# Altium schematic sheet FPGA_Power.SchDoc(FPGA_Power)
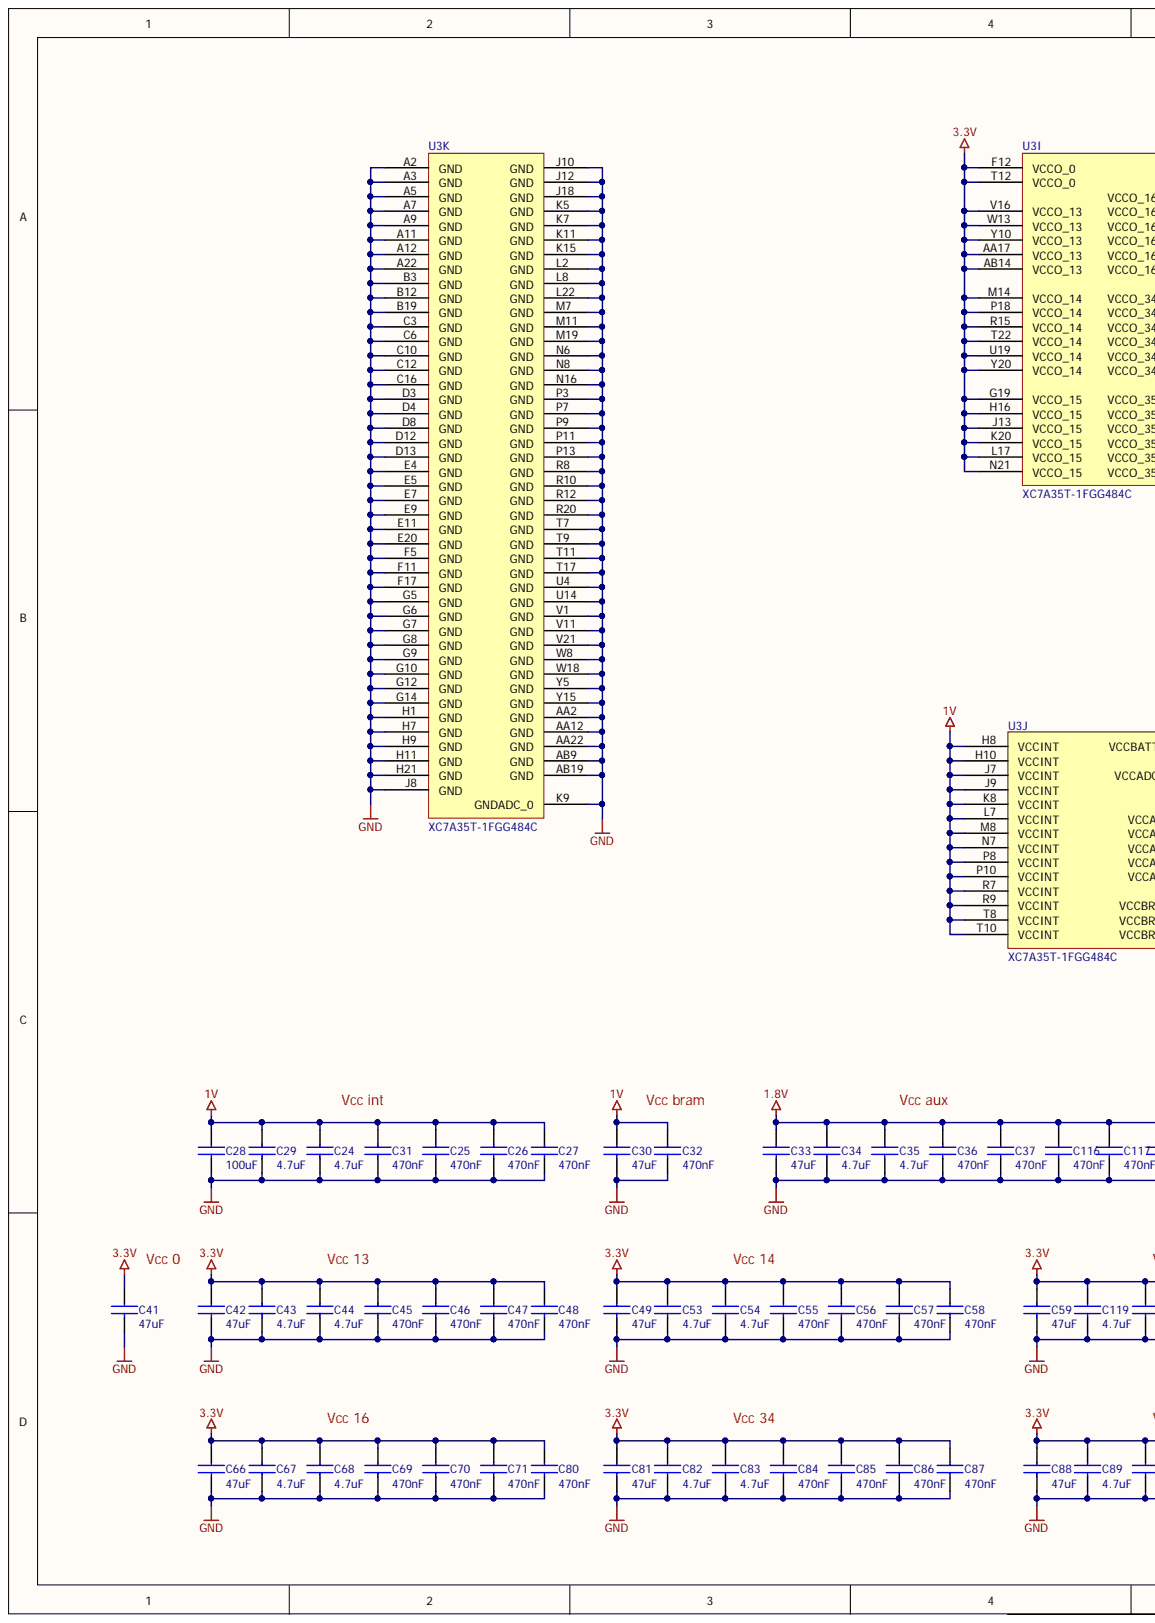
[diagram p0: FPGA_Power.SchDoc, left]
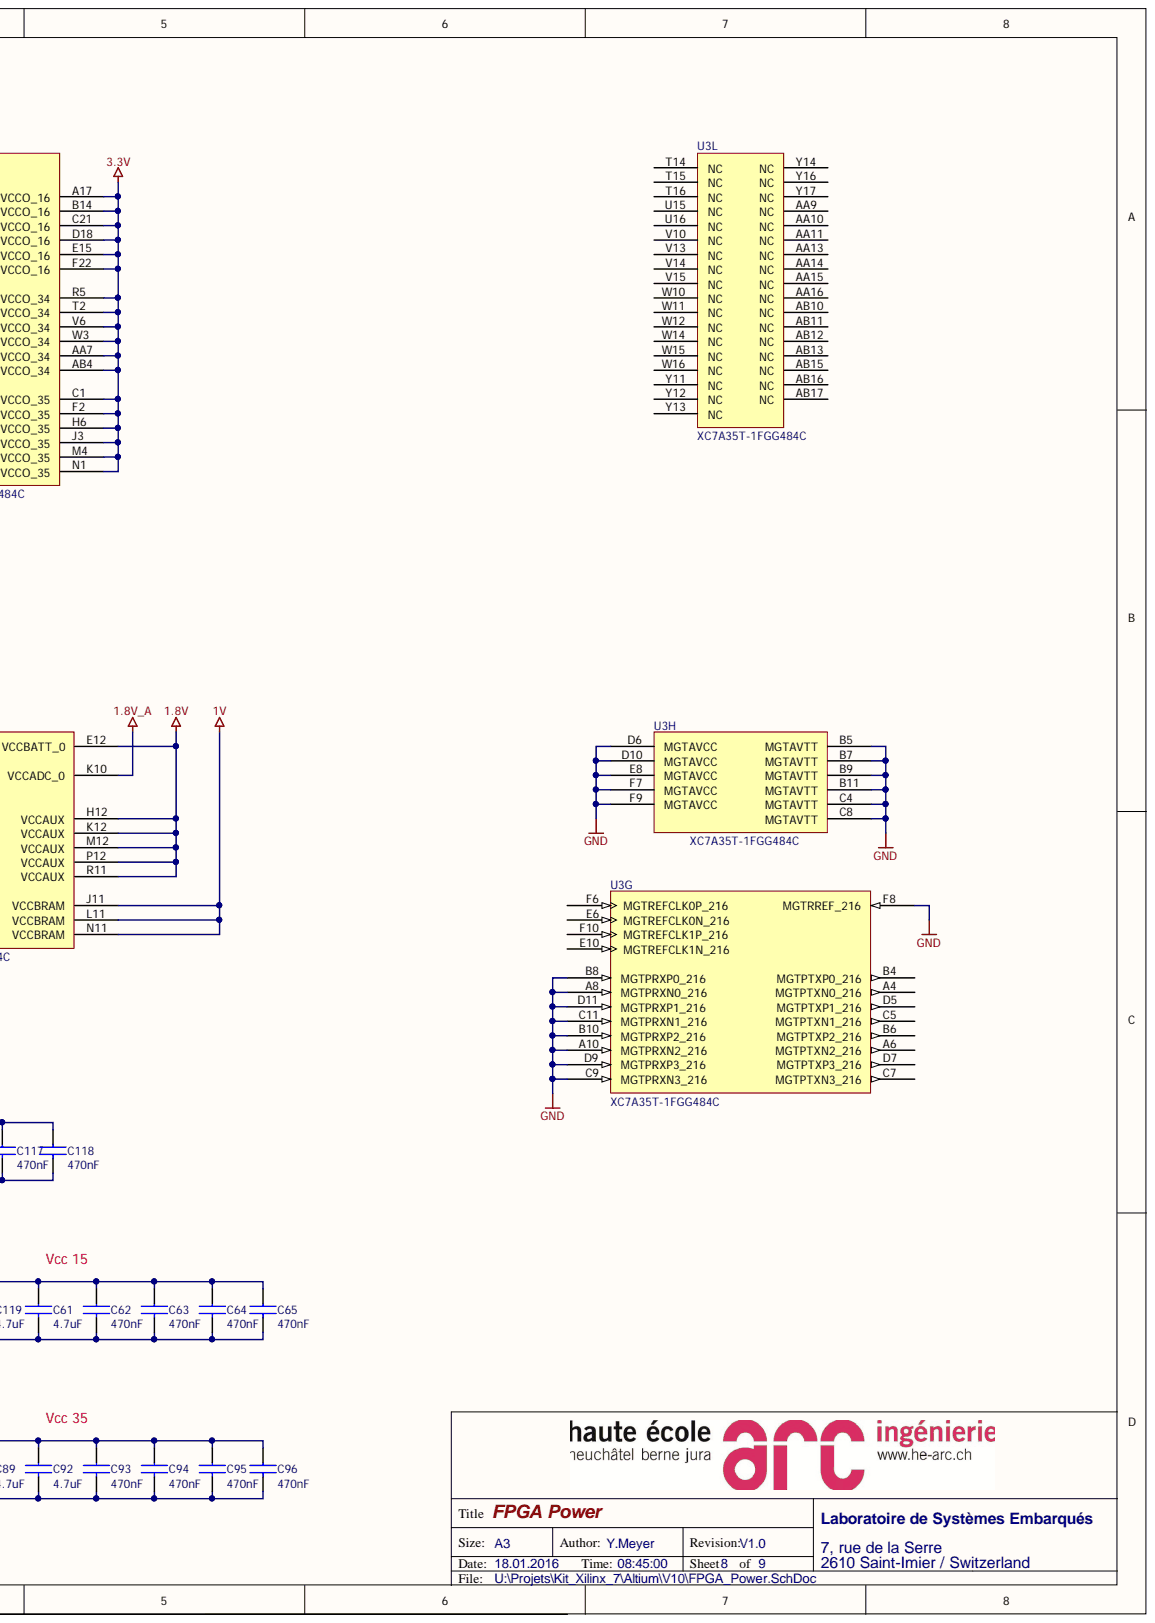
[diagram p1: FPGA_Power.SchDoc, right]

## Parts
C24  value 4.7uF  [080501]  (hidden comment: GRM21BR71A475KA73)
C25  value 470nF  [060301]  (hidden comment: GRM188R70J474KA01)
C26  value 470nF  [060301]  (hidden comment: GRM188R70J474KA01)
C27  value 470nF  [060301]  (hidden comment: GRM188R70J474KA01)
C28  value 100uF  [121001]  (hidden comment: GRM32ER60J107ME20L)
C29  value 4.7uF  [080501]  (hidden comment: GRM21BR71A475KA73)
C30  value 47uF  [121001]  (hidden comment: GRM32ER70J476ME20L)
C31  value 470nF  [060301]  (hidden comment: GRM188R70J474KA01)
C32  value 470nF  [060301]  (hidden comment: GRM188R70J474KA01)
C33  value 47uF  [121001]  (hidden comment: GRM32ER70J476ME20L)
C34  value 4.7uF  [080501]  (hidden comment: GRM21BR71A475KA73)
C35  value 4.7uF  [080501]  (hidden comment: GRM21BR71A475KA73)
C36  value 470nF  [060301]  (hidden comment: GRM188R70J474KA01)
C37  value 470nF  [060301]  (hidden comment: GRM188R70J474KA01)
C41  value 47uF  [121001]  (hidden comment: GRM32ER70J476ME20L)
C42  value 47uF  [121001]  (hidden comment: GRM32ER70J476ME20L)
C43  value 4.7uF  [080501]  (hidden comment: GRM21BR71A475KA73)
C44  value 4.7uF  [080501]  (hidden comment: GRM21BR71A475KA73)
C45  value 470nF  [060301]  (hidden comment: GRM188R70J474KA01)
C46  value 470nF  [060301]  (hidden comment: GRM188R70J474KA01)
C47  value 470nF  [060301]  (hidden comment: GRM188R70J474KA01)
C48  value 470nF  [060301]  (hidden comment: GRM188R70J474KA01)
C49  value 47uF  [121001]  (hidden comment: GRM32ER70J476ME20L)
C53  value 4.7uF  [080501]  (hidden comment: GRM21BR71A475KA73)
C54  value 4.7uF  [080501]  (hidden comment: GRM21BR71A475KA73)
C55  value 470nF  [060301]  (hidden comment: GRM188R70J474KA01)
C56  value 470nF  [060301]  (hidden comment: GRM188R70J474KA01)
C57  value 470nF  [060301]  (hidden comment: GRM188R70J474KA01)
C58  value 470nF  [060301]  (hidden comment: GRM188R70J474KA01)
C59  value 47uF  [121001]  (hidden comment: GRM32ER70J476ME20L)
C61  value 4.7uF  [080501]  (hidden comment: GRM21BR71A475KA73)
C62  value 470nF  [060301]  (hidden comment: GRM188R70J474KA01)
C63  value 470nF  [060301]  (hidden comment: GRM188R70J474KA01)
C64  value 470nF  [060301]  (hidden comment: GRM188R70J474KA01)
C65  value 470nF  [060301]  (hidden comment: GRM188R70J474KA01)
C66  value 47uF  [121001]  (hidden comment: GRM32ER70J476ME20L)
C67  value 4.7uF  [080501]  (hidden comment: GRM21BR71A475KA73)
C68  value 4.7uF  [080501]  (hidden comment: GRM21BR71A475KA73)
C69  value 470nF  [060301]  (hidden comment: GRM188R70J474KA01)
C70  value 470nF  [060301]  (hidden comment: GRM188R70J474KA01)
C71  value 470nF  [060301]  (hidden comment: GRM188R70J474KA01)
C80  value 470nF  [060301]  (hidden comment: GRM188R70J474KA01)
C81  value 47uF  [121001]  (hidden comment: GRM32ER70J476ME20L)
C82  value 4.7uF  [080501]  (hidden comment: GRM21BR71A475KA73)
C83  value 4.7uF  [080501]  (hidden comment: GRM21BR71A475KA73)
C84  value 470nF  [060301]  (hidden comment: GRM188R70J474KA01)
C85  value 470nF  [060301]  (hidden comment: GRM188R70J474KA01)
C86  value 470nF  [060301]  (hidden comment: GRM188R70J474KA01)
C87  value 470nF  [060301]  (hidden comment: GRM188R70J474KA01)
C88  value 47uF  [121001]  (hidden comment: GRM32ER70J476ME20L)
C89  value 4.7uF  [080501]  (hidden comment: GRM21BR71A475KA73)
C92  value 4.7uF  [080501]  (hidden comment: GRM21BR71A475KA73)
C93  value 470nF  [060301]  (hidden comment: GRM188R70J474KA01)
C94  value 470nF  [060301]  (hidden comment: GRM188R70J474KA01)
C95  value 470nF  [060301]  (hidden comment: GRM188R70J474KA01)
C96  value 470nF  [060301]  (hidden comment: GRM188R70J474KA01)
C116  value 470nF  [060301]  (hidden comment: GRM188R70J474KA01)
C117  value 470nF  [060301]  (hidden comment: GRM188R70J474KA01)
C118  value 470nF  [060301]  (hidden comment: GRM188R70J474KA01)
C119  value 4.7uF  [080501]  (hidden comment: GRM21BR71A475KA73)
U3  XC7A35T-1FGG484C  [FGG484]  (parts G,H,I,J,K,L)

## Nets
1.8V: C33.1 C34.1 C35.1 C36.1 C37.1 C116.1 C117.1 C118.1 U3.E12(VCCBATT_0) U3.H12(VCCAUX) U3.K12(VCCAUX) U3.M12(VCCAUX) U3.P12(VCCAUX) U3.R11(VCCAUX)
1.8V_A: U3.K10(VCCADC_0)
1V: C24.1 C25.1 C26.1 C27.1 C28.1 C29.1 C30.1 C31.1 C32.1 U3.H8(VCCINT) U3.H10(VCCINT) U3.J7(VCCINT) U3.J9(VCCINT) U3.J11(VCCBRAM) U3.K8(VCCINT) U3.L7(VCCINT) U3.L11(VCCBRAM) U3.M8(VCCINT) U3.N7(VCCINT) U3.N11(VCCBRAM) U3.P8(VCCINT) U3.P10(VCCINT) U3.R7(VCCINT) U3.R9(VCCINT) U3.T8(VCCINT) U3.T10(VCCINT)
3.3V: C41.1 C42.1 C43.1 C44.1 C45.1 C46.1 C47.1 C48.1 C49.1 C53.1 C54.1 C55.1 C56.1 C57.1 C58.1 C59.1 C61.1 C62.1 C63.1 C64.1 C65.1 C66.1 C67.1 C68.1 C69.1 C70.1 C71.1 C80.1 C81.1 C82.1 C83.1 C84.1 C85.1 C86.1 C87.1 C88.1 C89.1 C92.1 C93.1 C94.1 C95.1 C96.1 C119.1 U3.A17(VCCO_16) U3.AA7(VCCO_34) U3.AA17(VCCO_13) U3.AB4(VCCO_34) U3.AB14(VCCO_13) U3.B14(VCCO_16) U3.C1(VCCO_35) U3.C21(VCCO_16) U3.D18(VCCO_16) U3.E15(VCCO_16) U3.F2(VCCO_35) U3.F12(VCCO_0) U3.F22(VCCO_16) U3.G19(VCCO_15) U3.H6(VCCO_35) U3.H16(VCCO_15) U3.J3(VCCO_35) U3.J13(VCCO_15) U3.K20(VCCO_15) U3.L17(VCCO_15) U3.M4(VCCO_35) U3.M14(VCCO_14) U3.N1(VCCO_35) U3.N21(VCCO_15) U3.P18(VCCO_14) U3.R5(VCCO_34) U3.R15(VCCO_14) U3.T2(VCCO_34) U3.T12(VCCO_0) U3.T22(VCCO_14) U3.U19(VCCO_14) U3.V6(VCCO_34) U3.V16(VCCO_13) U3.W3(VCCO_34) U3.W13(VCCO_13) U3.Y10(VCCO_13) U3.Y20(VCCO_14)
GND: C24.2 C25.2 C26.2 C27.2 C28.2 C29.2 C30.2 C31.2 C32.2 C33.2 C34.2 C35.2 C36.2 C37.2 C41.2 C42.2 C43.2 C44.2 C45.2 C46.2 C47.2 C48.2 C49.2 C53.2 C54.2 C55.2 C56.2 C57.2 C58.2 C59.2 C61.2 C62.2 C63.2 C64.2 C65.2 C66.2 C67.2 C68.2 C69.2 C70.2 C71.2 C80.2 C81.2 C82.2 C83.2 C84.2 C85.2 C86.2 C87.2 C88.2 C89.2 C92.2 C93.2 C94.2 C95.2 C96.2 C116.2 C117.2 C118.2 C119.2 U3.A2(GND) U3.A3(GND) U3.A5(GND) U3.A7(GND) U3.A8(MGTPRXN0_216) U3.A9(GND) U3.A10(MGTPRXN2_216) U3.A11(GND) U3.A12(GND) U3.A22(GND) U3.AA2(GND) U3.AA12(GND) U3.AA22(GND) U3.AB9(GND) U3.AB19(GND) U3.B3(GND) U3.B5(MGTAVTT) U3.B7(MGTAVTT) U3.B8(MGTPRXP0_216) U3.B9(MGTAVTT) U3.B10(MGTPRXP2_216) U3.B11(MGTAVTT) U3.B12(GND) U3.B19(GND) U3.C3(GND) U3.C4(MGTAVTT) U3.C6(GND) U3.C8(MGTAVTT) U3.C9(MGTPRXN3_216) U3.C10(GND) U3.C11(MGTPRXN1_216) U3.C12(GND) U3.C16(GND) U3.D3(GND) U3.D4(GND) U3.D6(MGTAVCC) U3.D8(GND) U3.D9(MGTPRXP3_216) U3.D10(MGTAVCC) U3.D11(MGTPRXP1_216) U3.D12(GND) U3.D13(GND) U3.E4(GND) U3.E5(GND) U3.E7(GND) U3.E8(MGTAVCC) U3.E9(GND) U3.E11(GND) U3.E20(GND) U3.F5(GND) U3.F7(MGTAVCC) U3.F8(MGTRREF_216) U3.F9(MGTAVCC) U3.F11(GND) U3.F17(GND) U3.G5(GND) U3.G6(GND) U3.G7(GND) U3.G8(GND) U3.G9(GND) U3.G10(GND) U3.G12(GND) U3.G14(GND) U3.H1(GND) U3.H7(GND) U3.H9(GND) U3.H11(GND) U3.H21(GND) U3.J8(GND) U3.J10(GND) U3.J12(GND) U3.J18(GND) U3.K5(GND) U3.K7(GND) U3.K9(GNDADC_0) U3.K11(GND) U3.K15(GND) U3.L2(GND) U3.L8(GND) U3.L22(GND) U3.M7(GND) U3.M11(GND) U3.M19(GND) U3.N6(GND) U3.N8(GND) U3.N16(GND) U3.P3(GND) U3.P7(GND) U3.P9(GND) U3.P11(GND) U3.P13(GND) U3.R8(GND) U3.R10(GND) U3.R12(GND) U3.R20(GND) U3.T7(GND) U3.T9(GND) U3.T11(GND) U3.T17(GND) U3.U4(GND) U3.U14(GND) U3.V1(GND) U3.V11(GND) U3.V21(GND) U3.W8(GND) U3.W18(GND) U3.Y5(GND) U3.Y15(GND)

Not connected: U3 A4(MGTPTXN0_216) A6(MGTPTXN2_216) AA9(NC) AA10(NC) AA11(NC) AA13(NC) AA14(NC) AA15(NC) AA16(NC) AB10(NC) AB11(NC) AB12(NC) AB13(NC) AB15(NC) AB16(NC) AB17(NC) B4(MGTPTXP0_216) B6(MGTPTXP2_216) C5(MGTPTXN1_216) C7(MGTPTXN3_216) D5(MGTPTXP1_216) D7(MGTPTXP3_216) E6(MGTREFCLK0N_216) E10(MGTREFCLK1N_216) F6(MGTREFCLK0P_216) F10(MGTREFCLK1P_216) T14(NC) T15(NC) T16(NC) U15(NC) U16(NC) V10(NC) V13(NC) V14(NC) V15(NC) W10(NC) W11(NC) W12(NC) W14(NC) W15(NC) W16(NC) Y11(NC) Y12(NC) Y13(NC) Y14(NC) Y16(NC) Y17(NC)

## Library data of the parts
C24 C29 C34 C35 C43 C44 C53 C54 C61 C67 C68 C82 C83 C89 C92 C119 = CAPA: CAP CER 4.7UF 10V X7R 0805; Component Kind: Standard; Supplier 1: Digi-Key; Supplier Part Number 1: 490-6480-6-ND; Library Name: EISI.SchLib
C25-C27 C31 C32 C36 C37 C45-C48 C55-C58 C62-C65 C69-C71 C80 C84-C87 C93-C96 C116-C118 = CAPA: CAP CER 0.47UF 6.3V X7R 0603; Component Kind: Standard; Supplier 1: Digi-Key; Supplier Part Number 1: 490-6416-1-ND; Library Name: EISI.SchLib
C28 = CAPA: CAP CER 100UF 6.3V X5R 1210; Component Kind: Standard; Supplier 1: Digi-Key; Supplier Part Number 1: 490-3390-1-ND; Library Name: EISI.SchLib
C30 C33 C41 C42 C49 C59 C66 C81 C88 = CAPA: CAP CER 47UF 6.3V X7R 1210; Component Kind: Standard; Supplier 1: Digi-Key; Supplier Part Number 1: 490-6542-1-ND; Library Name: EISI.SchLib
U3 = XC7A35T-1FGG484C: Artix-7 FPGA, 250 User I/Os, 4 GTP, 484-Ball BGA, Speed Grade 1, Commercial Grade, Pb-Free; Manufacturer 1: Xilinx; Component Kind: Standard; Library Name: KitXilinx7.SCHLIB; Manufacturer Part Number 1: XC7A35T-1FGG484C; Supplier 1: Avnet Express; Supplier Part Number 1: XC7A35T-1FGG484C; Supplier Subtotal 1: 2140; Supplier Unit Price 1: 52.1952
